# Altium schematic sheet ActuatorR.SchDoc(ActuatorR)
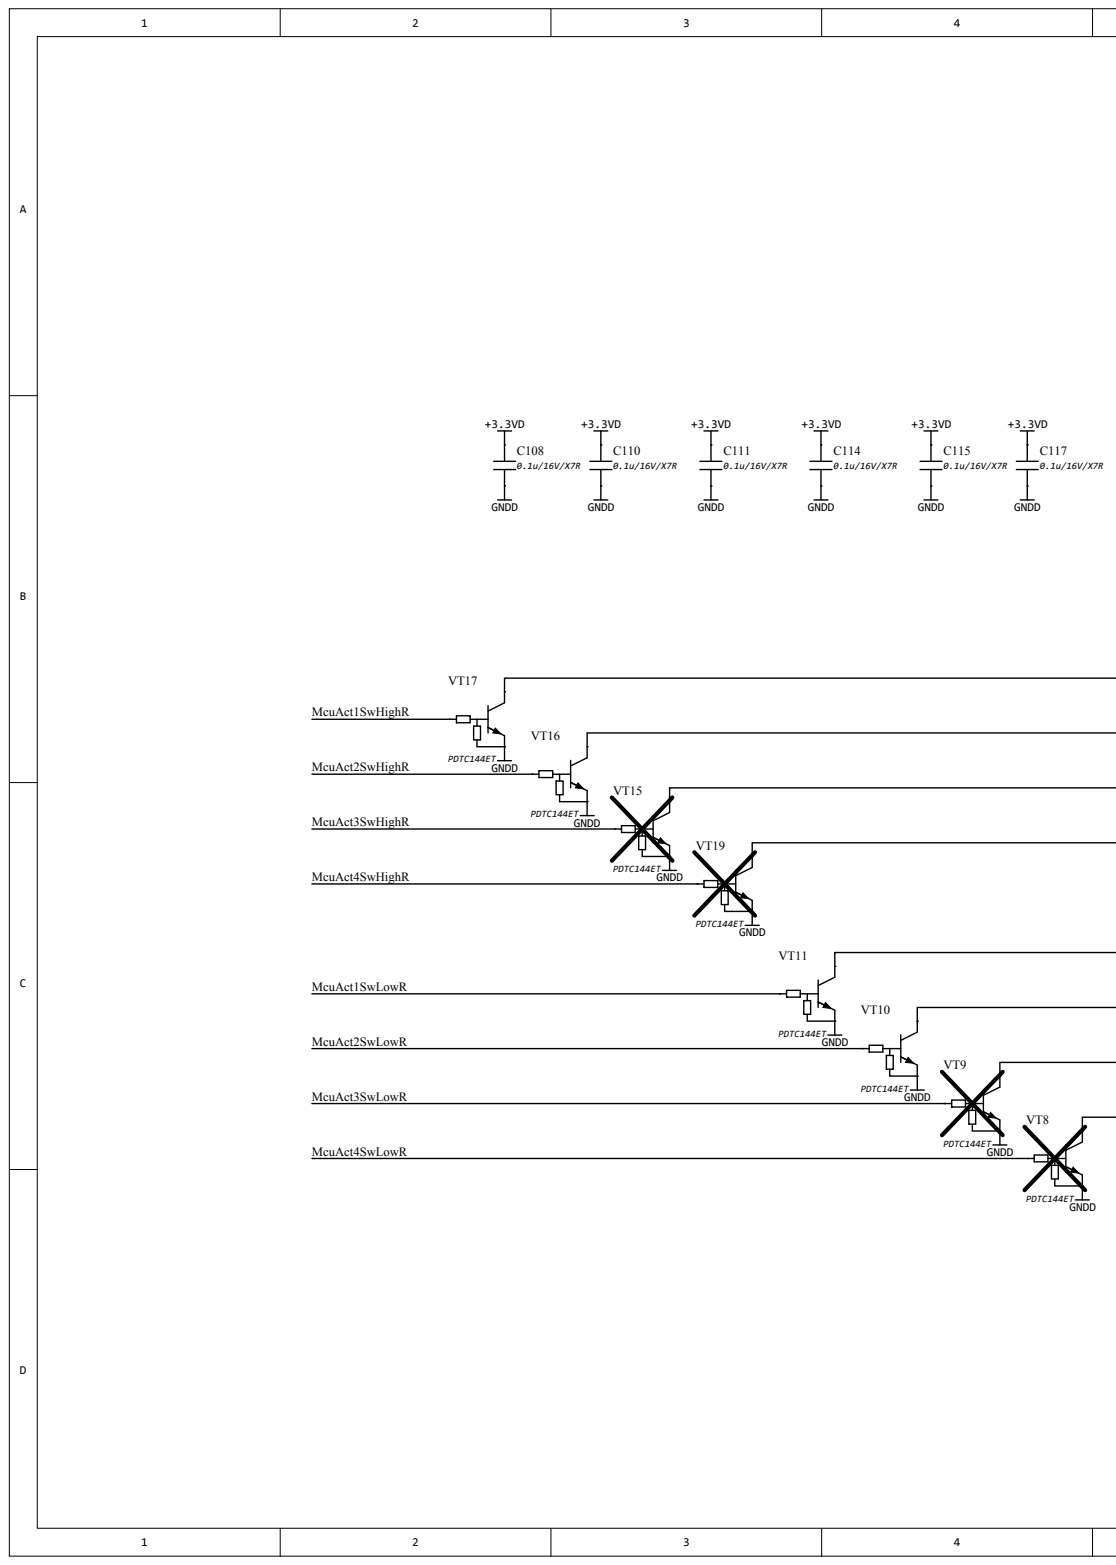
[diagram p0: ActuatorR.SchDoc, left]
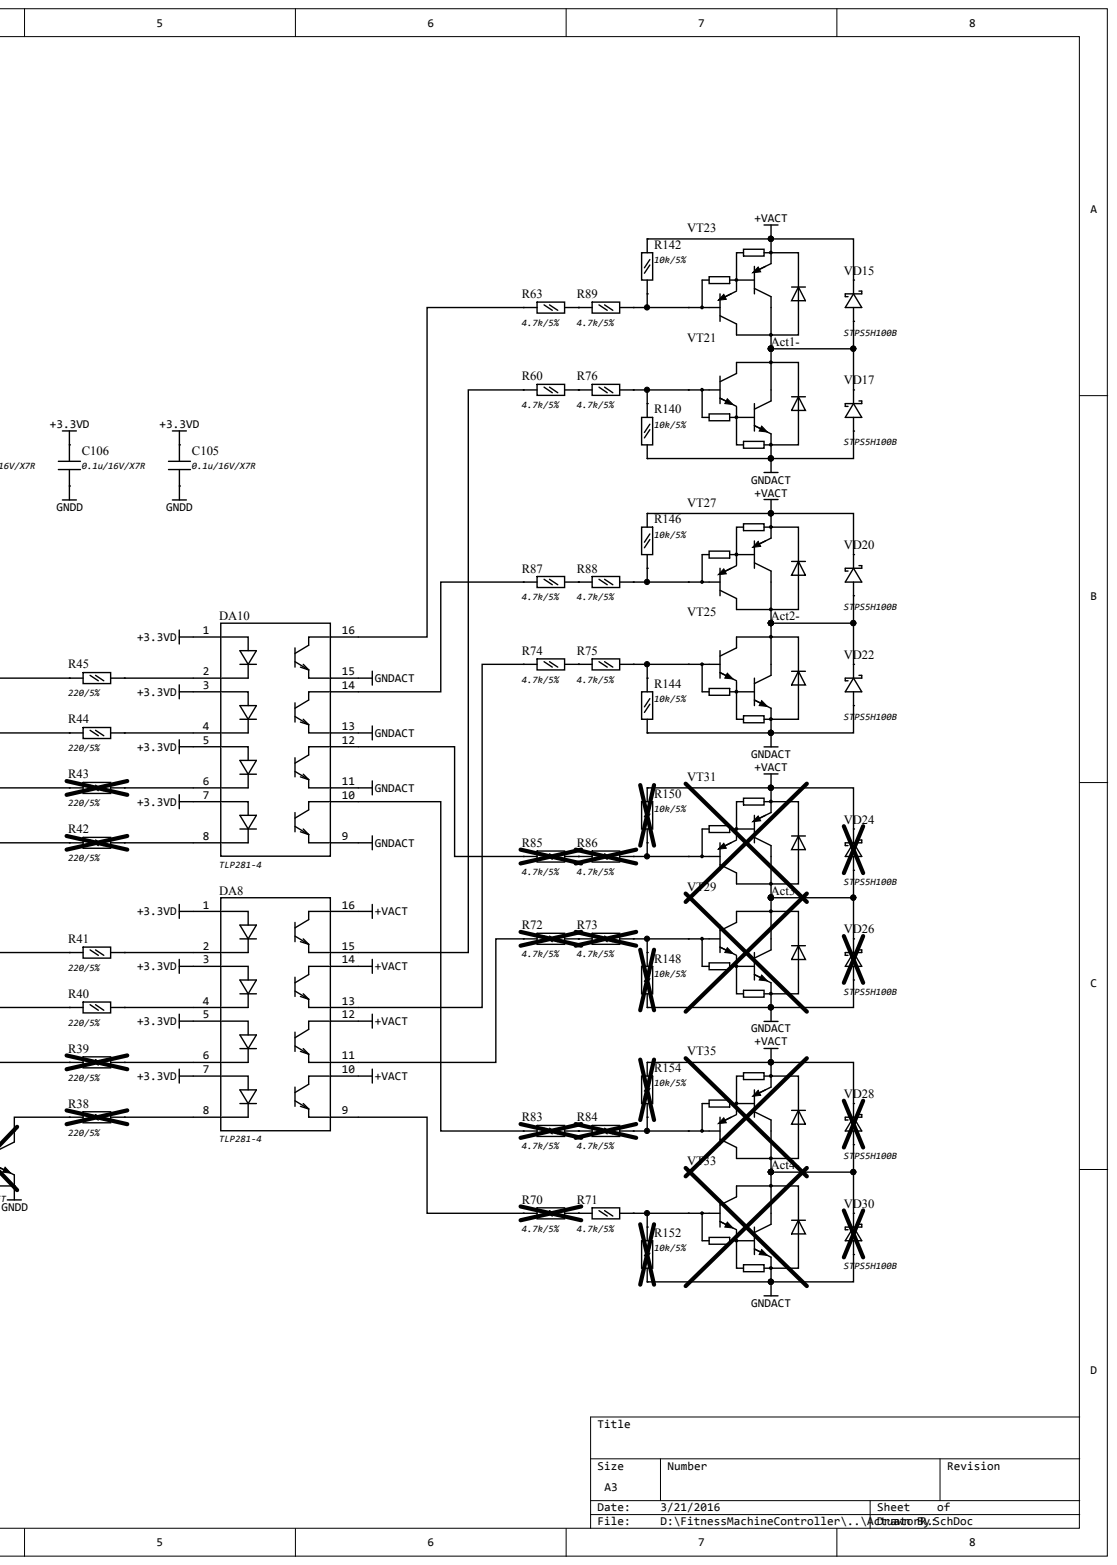
[diagram p1: ActuatorR.SchDoc, right]

## Parts
C105  0.1u/16V/X7R
C106  0.1u/16V/X7R
C108  0.1u/16V/X7R
C110  0.1u/16V/X7R
C111  0.1u/16V/X7R
C114  0.1u/16V/X7R
C115  0.1u/16V/X7R
C117  0.1u/16V/X7R
DA8  TLP281-4
DA10  TLP281-4
R38  220/5%
R39  220/5%
R40  220/5%
R41  220/5%
R42  220/5%
R43  220/5%
R44  220/5%
R45  220/5%
R60  4.7k/5%
R63  4.7k/5%
R70  4.7k/5%
R71  4.7k/5%
R72  4.7k/5%
R73  4.7k/5%
R74  4.7k/5%
R75  4.7k/5%
R76  4.7k/5%
R83  4.7k/5%
R84  4.7k/5%
R85  4.7k/5%
R86  4.7k/5%
R87  4.7k/5%
R88  4.7k/5%
R89  4.7k/5%
R140  10k/5%
R142  10k/5%
R144  10k/5%
R146  10k/5%
R148  10k/5%
R150  10k/5%
R152  10k/5%
R154  10k/5%
VD15  STPS5H100B
VD17  STPS5H100B
VD20  STPS5H100B
VD22  STPS5H100B
VD24  STPS5H100B
VD26  STPS5H100B
VD28  STPS5H100B
VD30  STPS5H100B
VT8  PDTC144ET
VT9  PDTC144ET
VT10  PDTC144ET
VT11  PDTC144ET
VT15  PDTC144ET
VT16  PDTC144ET
VT17  PDTC144ET
VT19  PDTC144ET
VT21  BJT_NPN_DARLINGTON_TO220  (value not drawn)
VT23  BJT_PNP_DARLINGTON_TO220  (value not drawn)
VT25  BJT_NPN_DARLINGTON_TO220  (value not drawn)
VT27  BJT_PNP_DARLINGTON_TO220  (value not drawn)
VT29  BJT_NPN_DARLINGTON_TO220  (value not drawn)
VT31  BJT_PNP_DARLINGTON_TO220  (value not drawn)
VT33  BJT_NPN_DARLINGTON_TO220  (value not drawn)
VT35  BJT_PNP_DARLINGTON_TO220  (value not drawn)

## Nets
+3.3VD: C105.2 C106.2 C108.2 C110.2 C111.2 C114.2 C115.2 C117.2 DA8.1 DA8.3 DA8.5 DA8.7 DA10.1 DA10.3 DA10.5 DA10.7
+VACT: DA8.10 DA8.12 DA8.14 DA8.16 R142.2 R146.2 R150.2 R154.2 VD15.3 VD20.3 VD24.3 VD28.3 VT23.3 VT27.3 VT31.3 VT35.3
GNDACT: DA10.9 DA10.11 DA10.13 DA10.15 R140.1 R144.1 R148.1 R152.1 VD17.2 VD22.2 VD26.2 VD30.2 VT21.3 VT25.3 VT29.3 VT33.3
GNDD: C105.1 C106.1 C108.1 C110.1 C111.1 C114.1 C115.1 C117.1 VT8.2 VT9.2 VT10.2 VT11.2 VT15.2 VT16.2 VT17.2 VT19.2
ACT1-: VD15.2 VD17.3 VT21.2 VT23.2
ACT2-: VD20.2 VD22.3 VT25.2 VT27.2
ACT3-: VD24.2 VD26.3 VT29.2 VT31.2
ACT4-: VD28.2 VD30.3 VT33.2 VT35.2
MCUACT1SWHIGHR: VT17.1
MCUACT1SWLOWR: VT11.1
MCUACT2SWHIGHR: VT16.1
MCUACT2SWLOWR: VT10.1
MCUACT3SWHIGHR: VT15.1
MCUACT3SWLOWR: VT9.1
MCUACT4SWHIGHR: VT19.1
MCUACT4SWLOWR: VT8.1
NetDA8_2: DA8.2 R41.1
NetDA8_4: DA8.4 R40.1
NetDA8_6: DA8.6 R39.1
NetDA8_8: DA8.8 R38.1
NetDA8_9: DA8.9 R70.2
NetDA8_11: DA8.11 R72.2
NetDA8_13: DA8.13 R74.2
NetDA8_15: DA8.15 R60.2
NetDA10_2: DA10.2 R45.1
NetDA10_4: DA10.4 R44.1
NetDA10_6: DA10.6 R43.1
NetDA10_8: DA10.8 R42.1
NetDA10_10: DA10.10 R83.2
NetDA10_12: DA10.12 R85.2
NetDA10_14: DA10.14 R87.2
NetDA10_16: DA10.16 R63.2
NetR38_2: R38.2 VT8.3
NetR39_2: R39.2 VT9.3
NetR40_2: R40.2 VT10.3
NetR41_2: R41.2 VT11.3
NetR42_2: R42.2 VT19.3
NetR43_2: R43.2 VT15.3
NetR44_2: R44.2 VT16.3
NetR45_2: R45.2 VT17.3
NetR60_1: R60.1 R76.2
NetR63_1: R63.1 R89.2
NetR70_1: R70.1 R71.2
NetR71_1: R71.1 R152.2 VT33.1
NetR72_1: R72.1 R73.2
NetR73_1: R73.1 R148.2 VT29.1
NetR74_1: R74.1 R75.2
NetR75_1: R75.1 R144.2 VT25.1
NetR76_1: R76.1 R140.2 VT21.1
NetR83_1: R83.1 R84.2
NetR84_1: R84.1 R154.1 VT35.1
NetR85_1: R85.1 R86.2
NetR86_1: R86.1 R150.1 VT31.1
NetR87_1: R87.1 R88.2
NetR88_1: R88.1 R146.1 VT27.1
NetR89_1: R89.1 R142.1 VT23.1

## Library data of the parts
C105 C106 C108 C110 C111 C114 C115 C117 = (no symbol name): ComponentDescriptionRUS: Конденсатор SMD 0603; Analog: нет; NumberSolderPointsSMD: 0; NumberSolderPointsTHT: 0
DA8 DA10 = (no symbol name): ComponentDescriptionRUS: Оптрон; Аналог: TLP291-4
R38-R45 R60 R63 R70-R76 R83-R89 R140 R142 R144 R146 R148 R150 R152 R154 = (no symbol name): ComponentDescriptionRUS: Резистор SMD 0603; Analog: нет; NumberSolderPointsSMD: 0; NumberSolderPointsTHT: 0
VD15 VD17 VD20 VD22 VD24 VD26 VD28 VD30 VT23 VT27 VT31 VT35 = (no symbol name): Analog: нет; NumberSolderPointsSMD: 0; NumberSolderPointsTHT: 0
VT8-VT11 VT15-VT17 VT19 VT21 VT25 VT29 VT33 = (no symbol name): ComponentDescriptionRUS: Транзистор SOT23; Analog: нет; NumberSolderPointsSMD: 0; NumberSolderPointsTHT: 0
